# Altium schematic sheet Khoi_Nguon.SchDoc
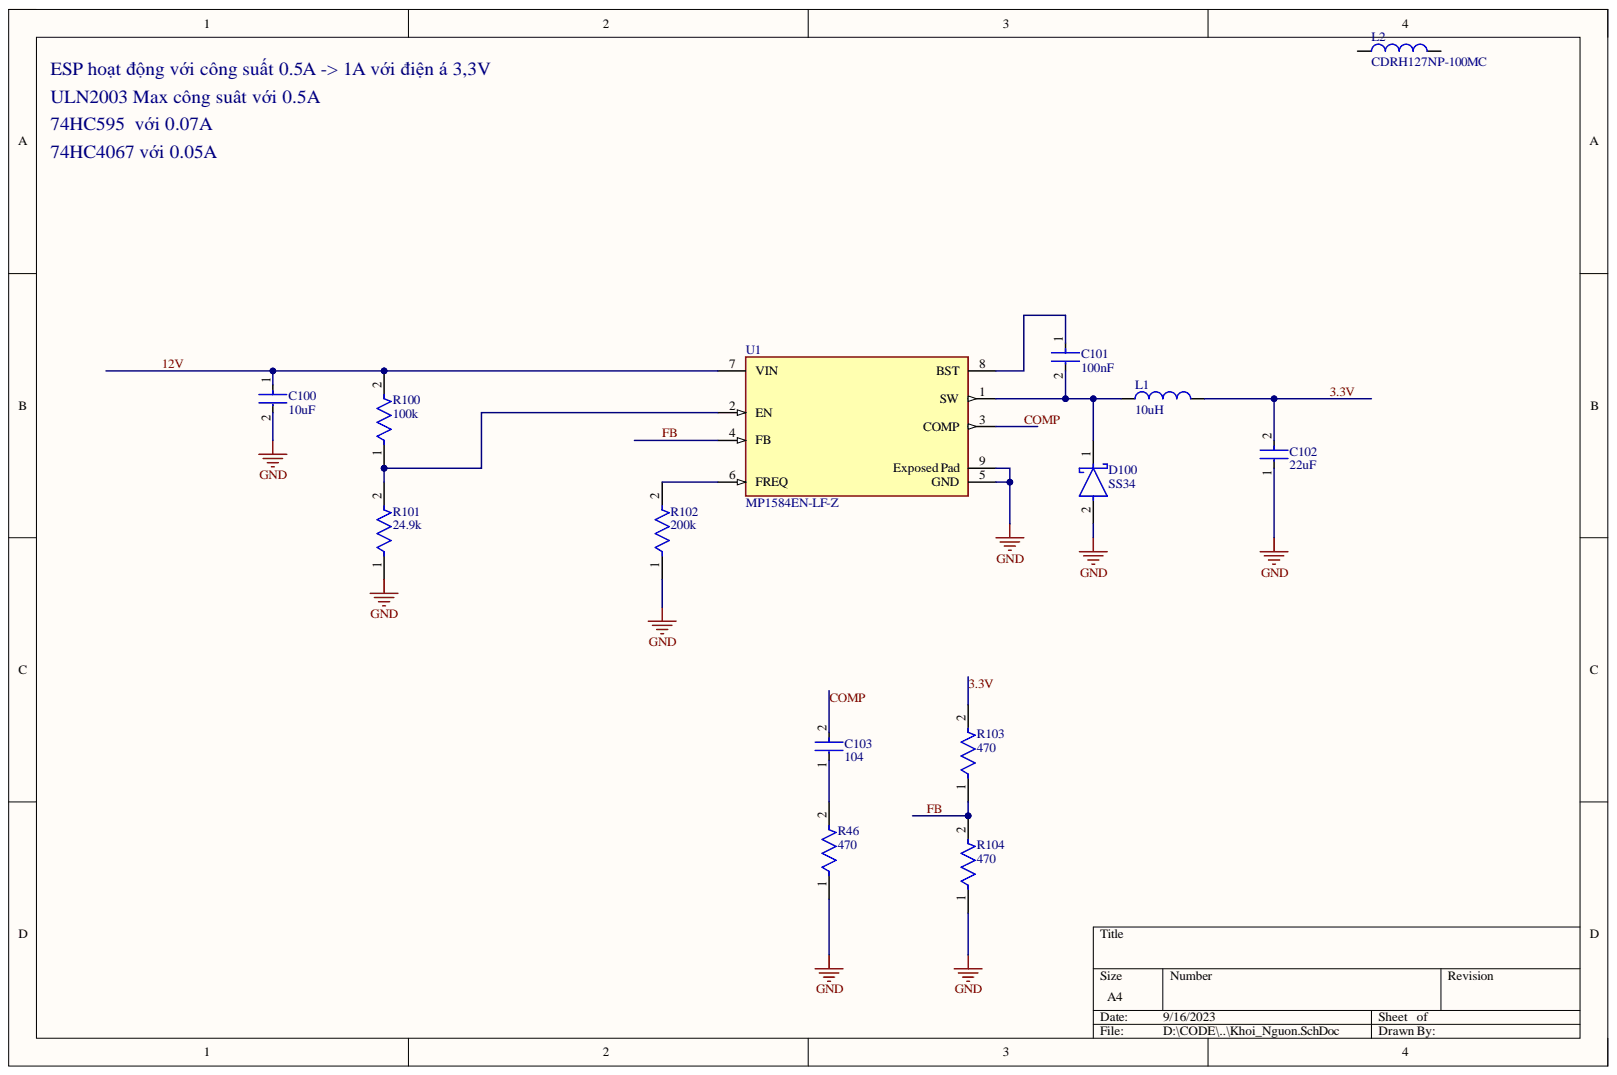
[diagram p0: Khoi_Nguon.SchDoc]

## Parts
C100  10uF  [FP-GRM188-0_1-e0_2_0_55-IPC_A]
C101  100nF  [FP-GRM188-0_1-e0_2_0_55-IPC_A]
C102  22uF  [FP-GRM15-MFG]
C103  104  [FP-GRM15-MFG]
D100  SS34  [FP-SS34LWH-MFG]
L1  10uH  [SUMI-CDRH127_V]
L2  CDRH127NP-100MC  [SUMI-CDRH127_V]
R46  470  [FP-ERJ2RK-MFG]
R100  100k  [FP-ERJ2RK-MFG]
R101  24.9k  [FP-ERJ2RK-MFG]
R102  200k  [FP-ERJ2RK-MFG]
R103  470  [FP-ERJ2RK-MFG]
R104  470  [FP-ERJ2RK-MFG]
U1  MP1584EN-LF-Z  [FP-MP1584EN-LF-Z-MFG]

## Nets
GND: C100.2 C102.1 D100.2 R46.1 R101.1 R102.1 R104.1 U1.5(GND) U1.9(Exposed_Pad)
3.3V: C102.2 L1.2 R103.2
12V: C100.1 R100.2 U1.7(VIN)
COMP: C103.2 U1.3(COMP)
FB: R103.1 R104.2 U1.4(FB)
NetC101_1: C101.1 U1.8(BST)
NetC101_2: C101.2 D100.1 L1.1 U1.1(SW)
NetC103_1: C103.1 R46.2
NetR100_1: R100.1 R101.2 U1.2(EN)
NetR102_2: R102.2 U1.6(FREQ)

Not connected: L2 1 2

## Library data of the parts
C100 C101 = CMP-2006-02792-2: Chip Multilayer Ceramic Capacitors for General Purpose, 0603, 10uF, X5R, 15%, 20%, 6.3V; Capacitance: 10uF; Case Code (Imperial): 0603; Case Code (Metric): 1608; Case/Package: 0603; Component Kind: Standard; Depth: 0.8mm; Dielectric: X5R; Height: 0.8mm; Lead Free: Lead Free; Length: 1.6mm; Library Name: Altium Content Vault; Material: Ceramic; Max Operating Temperature: 85°C; Min Operating Temperature: -55°C; Mount: Surface Mount; Package Quantity: 4000; Packaging: Tape and Reel; Radiation Hardening: No; REACH SVHC: No SVHC; Termination: SMD/SMT; Thickness: 0.035inch; Tolerance: 20%; Voltage: 6.3V; Voltage Rating: 6.3V; Voltage Rating (DC): 6.3V; Width: 0.031inch
C102 C103 = CMP-2100-03641-2: CAP CER 0.1UF 16V X7R 0402; Manufacturer: Murata; Manufacturer Part Number: GRM155R71C104KA88D; Capacitance: 0.10μF; Material: Ceramic; Standoff Height: 0mm; Tolerance: 10%; Value: 0.10μF; Voltage Rating: 16Vdc; Category: Cap; Component Kind: Standard; ComponentLink2Description: Datasheet; ComponentLink2URL: https://search.murata.co.jp/Ceramy/image/img/A01X/G101/ENG/GRM155R71H471KA01-01A.pdf; Device Class L1: Passive Components; Device Class L2: Capacitors; Device Class L3: Ceramic Capacitors; Digikey Description: CAP CER 0.1UF 16V X7R 0402; Height: 0.55mm; Ipc Land Pattern Name: CAPC10050X219; Lead Free: yes; Library Name: Altium Content Vault; Mouser Description: 0402 0.1 uF 16V ±10 % Tolerance X7R SMT Multilayer Ceramic Capacitor; Octopart: https://octopart.com/grm155r71c104ka88d-murata-196880; Package: GRM15; RoHS: TRUE; Temperature: -55°C to +125°C; Temperature Characteristic: X7R; Temperature Range High: +125°C; Temperature Range Low: -55°C
D100 = CMP-15604-000001-1: DIODE SCHOTTKY 40V 3A SOD123W; Component Kind: Standard; Library Name: Altium Content Vault; RoHS Compliant: Yes
L1 L2 = CMP-2000-06084-1: Power Inductor, 10 uH, +/- 20%, -40 to 100 degC, 2-Pin SMD, RoHS, Tape and Reel; Manufacturer: Sumida; Catalog Drawings: CDRH127SeriesFrontCDRH127SeriesSideCDRH127SeriesBottom; Current Rating: 5.4A; DC Resistance (DCR): 21.6mOhmMax; Frequency - Test: 1kHz; Inductance: 10µH; Online Catalog: CDRHSeries; Other Names: 308-1457-1; Product Training Modules: PowerInductorBasics; Shielding: Shielded; Category: Inductors,Coils,Chokes; Component Kind: Standard; Current - Saturation: -; Datasheet URL: http://products.sumida.com/products/pdf/CDRH127.pdf; Datasheet Version: 01/2014; Family: FixedInductors; Frequency - Self Resonant: -; Height - Seated (Max): 0.315"(8.00mm); Library Name: Altium Content Vault; Manufacturer URL: http://www.sumida.com/; Material - Core: -; Mounting Technology: SurfaceMount; Mounting Type: SurfaceMount; Operating Temperature: -40°C~100°C; Package / Case: Nonstandard; Package Description: 2-Pin Surface Mount Device, Body 12 x 12 mm; Package Reference: CDRH127; Packaging: CutTape(CT); Q @ Freq: -; Ratings: -; Series: CDRH127; Size / Dimension: 0.472"Lx0.472"W(12.00mmx12.00mm); Standard Package: 1; Tolerance: ±20%; Type: -
R46 R100-R104 = CMP-2002-00608-4: RES SMD 100K OHM 1% 1/10W 0402; Max Power Dissipation: 100mW; Resistance: 100kR; Temperature Coefficient: 100ppm/°C; Voltage Rating: 50V; Case Code (Imperial): 0402; Case Code (Metric): 1005; Case/Package: 0402; Component Kind: Standard; Composition: Thick Film; Contact Plating: Tin; Depth: 0.5mm; Features: Automotive AEC-Q200; Height: 0.35mm; Lead Free: Lead Free; Length: 1mm; Library Name: Altium Content Vault; Max Operating Temperature: 155°C; Military Standard: Not; Min Operating Temperature: -55°C; Mount: Surface Mount; Number of Pins: 2; Number of Terminations: 2; Package Quantity: 1; Packaging: Tape and Reel; Power Rating: 100mW; Radiation Hardening: No; REACH SVHC: No SVHC; Termination: SMD/SMT; Tolerance: 1%; Voltage Rating (DC): 50V; Width: 0.5mm
U1 = CMP-57379-000006-1: IC REG BUCK ADJ 3A; Manufacturer: Monolithic Power Systems; Max Junction Temp: +125°C; Max Power Dissipation: 2.5W; Max Supply Voltage: 28V; Min Supply Voltage: 4.5V; Nominal Supply Current: 100uA; Number Of Outputs: 1; Output Type: AdjustableProgrammable; Output Voltage: 0.8-25V; Standoff Height: 0mm; Switching Frequency: 100-1500kHz; Ambient Temperature Range High: +85°C; Ambient Temperature Range Low: -20°C; Automotive: No; Category: IC; Component Kind: Standard; ComponentLink2Description: Datasheet; ComponentLink2URL: https://www.monolithicpower.com/en/documentview/productdocument/index/doc_url/L01QMTU4NF9yMS4wLnBkZg%3D%3D/release_date/MjAwOS0wNC0wOSAwMDowMDowMA%3D%3D/; Device Class L1: Integrated Circuits (ICs); Device Class L2: Power Management ICs; Device Class L3: Voltage Regulators - Switching; Digikey Description: IC REG BUCK ADJ 3A; Height: 1.7mm; Ipc Land Pattern Name: SOIC127P600X150-8; Lead Free: Yes; Library Name: Altium Content Vault; Manufacturer Part Number: MP1584EN-LF-Z; Octopart: https://octopart.com/mp1584en-lf-z-monolithic+power+systems-18629680; Output Current: 3A; Package: MP1584EN-LF-Z; RoHS: TRUE; Switching Topology: Buck; Temperature: -20°C to +125°C; Temperature Range High: +125°C; Temperature Range Low: -20°C
